# Altium schematic sheet 4.RS485.SchDoc
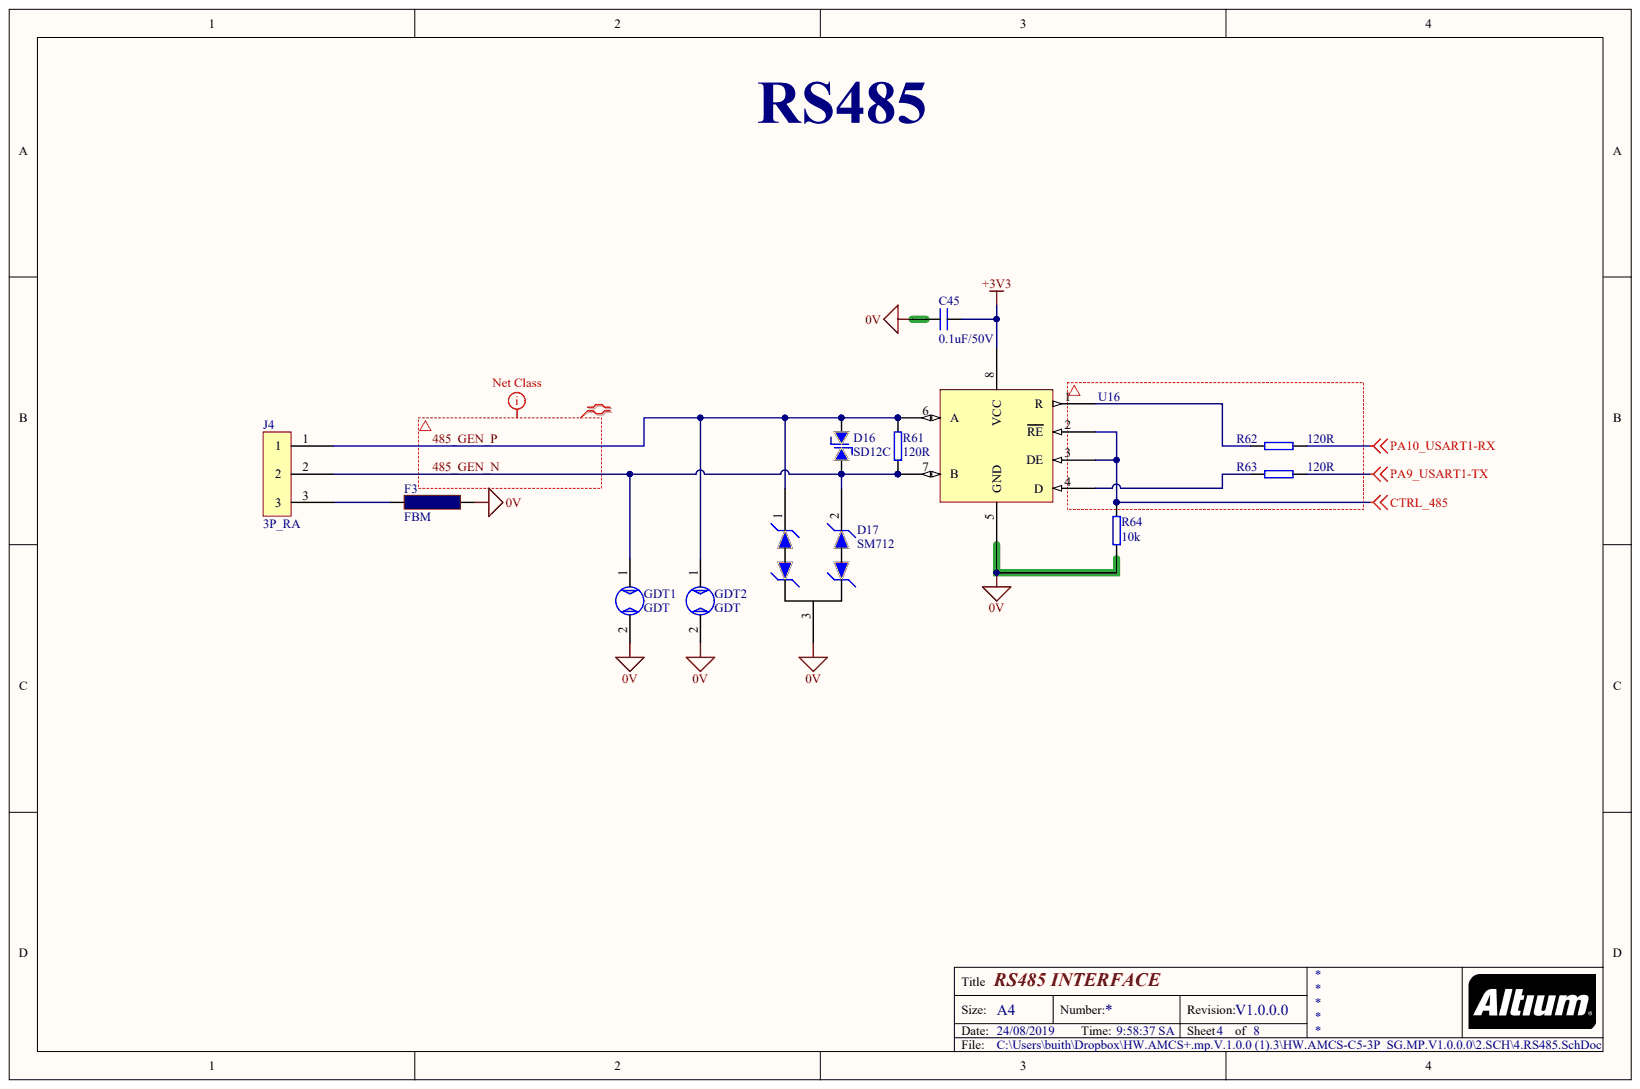
[diagram p0: 4.RS485.SchDoc]

## Parts
C45  0.1uF/50V  [0805]
D16  SD12C  [SOD-323]
D17  SM712  [SOT-23]
F3  FBM  [0805]
GDT1  GDT  [GDT-2031-23T]
GDT2  GDT  [GDT-2031-23T]
J4  3P_RA  [CONN 3x1 3.81MM]
R61  120R  [0805]
R62  120R  [0805]
R63  120R  [0805]
R64  10k  [0805]
U16  SN65HVD11  [SOIC-8_3.9]  (value not drawn)

## Nets
0V: C45.2 D17.3 F3.1 GDT1.2 GDT2.2 R64.2 U16.5(GND)
+3V3: C45.1 U16.8(VCC)
CTRL_485: R64.1 U16.2(RE) U16.3(DE)
PA9_USART1-TX: R63.2
PA10_USART1-RX: R62.2
485_GEN_N: D16.1 D17.2 GDT1.1 J4.2 R61.1 U16.7(B)
485_GEN_P: D16.2 D17.1 GDT2.1 J4.1 R61.2 U16.6(A)
NetF3_2: F3.2 J4.3
NetR62_1: R62.1 U16.1(R)
NetR63_1: R63.1 U16.4(D)
N00110:
N00111:

## Library data of the parts
C45 = Cap_0.1uF: CAP CER 0.1UF 50V Y5V 0805; MFR: Yageo; Part Number: CC0805ZRY5V9BB104; AMCS CODE: VT-CAP-0004; ASS: SMT; Component Kind: Standard; Package: 0805; Library Name: Schlib_Diepvn_V01.SchLib
D16 = TVS_RS485: TVS DIODE 12VWM 24VC SOD323; MFR: DIODE Inc; Part Number: D12V0H1U2WS-7; AMCS CODE: VT-DIO-0008; Component Kind: Standard; Package: SC-76, SOD-323; Library Name: Schlib_Diepvn_V01.SchLib
D17 = SM712: TVS DIODE 12VWM 31VC SOT23; MFR: Littelfuse Inc.; Part Number: SM712-02HTG; AMCS CODE: VT-DIO-0009; Component Kind: Standard; Package: SOT23; Library Name: Schlib_Diepvn_V01.SchLib
F3 = FERRITBEAD_400mA: FERRITE BEAD 60 OHM 0805 1LN; MFR: Taiyo Yuden; Part Number: BKP2125HS600-T; AMCS CODE: VT-IND-0001; Component Kind: Standard; Package: 0805; Library Name: Schlib_Diepvn_V01.SchLib
GDT1 GDT2 = GDT: GDT 185V 1KA SURFACE MOUNT; MFR: Bourns Inc.; Part Number: 2031-23T-SM-RPLF; AMCS CODE: VT-PRT-00004; Component Kind: Standard; Package: Refer to datasheet; Library Name: Schlib_Diepvn_V01.SchLib
J4 = CONN_3x1_3.81mm: TERM BLOCK 3POS SIDE ENT 3.81MM 90 DEG; MFR: TE; Part Number: 284513-3; AMCS CODE: VT-CON-0014; ASS: TH; Component Kind: Standard; Package: Pitch: 3.81MM; TYPE: CONN; Library Name: Schlib_Diepvn_V01.SchLib
R61-R63 = Res_100R: RES SMD 120 OHM 1% 1/8W 0805; MFR: Yageo; Part Number: RC0805FR-07120RL; AMCS CODE: VT-RES-0008; Component Kind: Standard; Package: 0805; Library Name: Schlib_Diepvn_V01.SchLib
R64 = Res_10k: RES SMD 10K OHM 1% 1/8W 0805; MFR: Yageo; Part Number: RC0805FR-0710KL; AMCS CODE: VT-RES-0001; ASS: SMT; Component Kind: Standard; Package: 0805; Library Name: Schlib_Diepvn_V01.SchLib
U16 = SN65HVD11: IC RS485 TRANSCEIVER 3.3V 8-SOIC; MFR: TI; Part Number: SN65HVD11DR; Vender Part: 296-31717-1-ND; AMCS CODE: VT-IC-0008; Vender: Digikey; Component Kind: Standard; Package: SOIC-8; Library Name: Schlib_Diepvn_V01.SchLib
